# Altium schematic sheet Bramka_Main.SchDoc
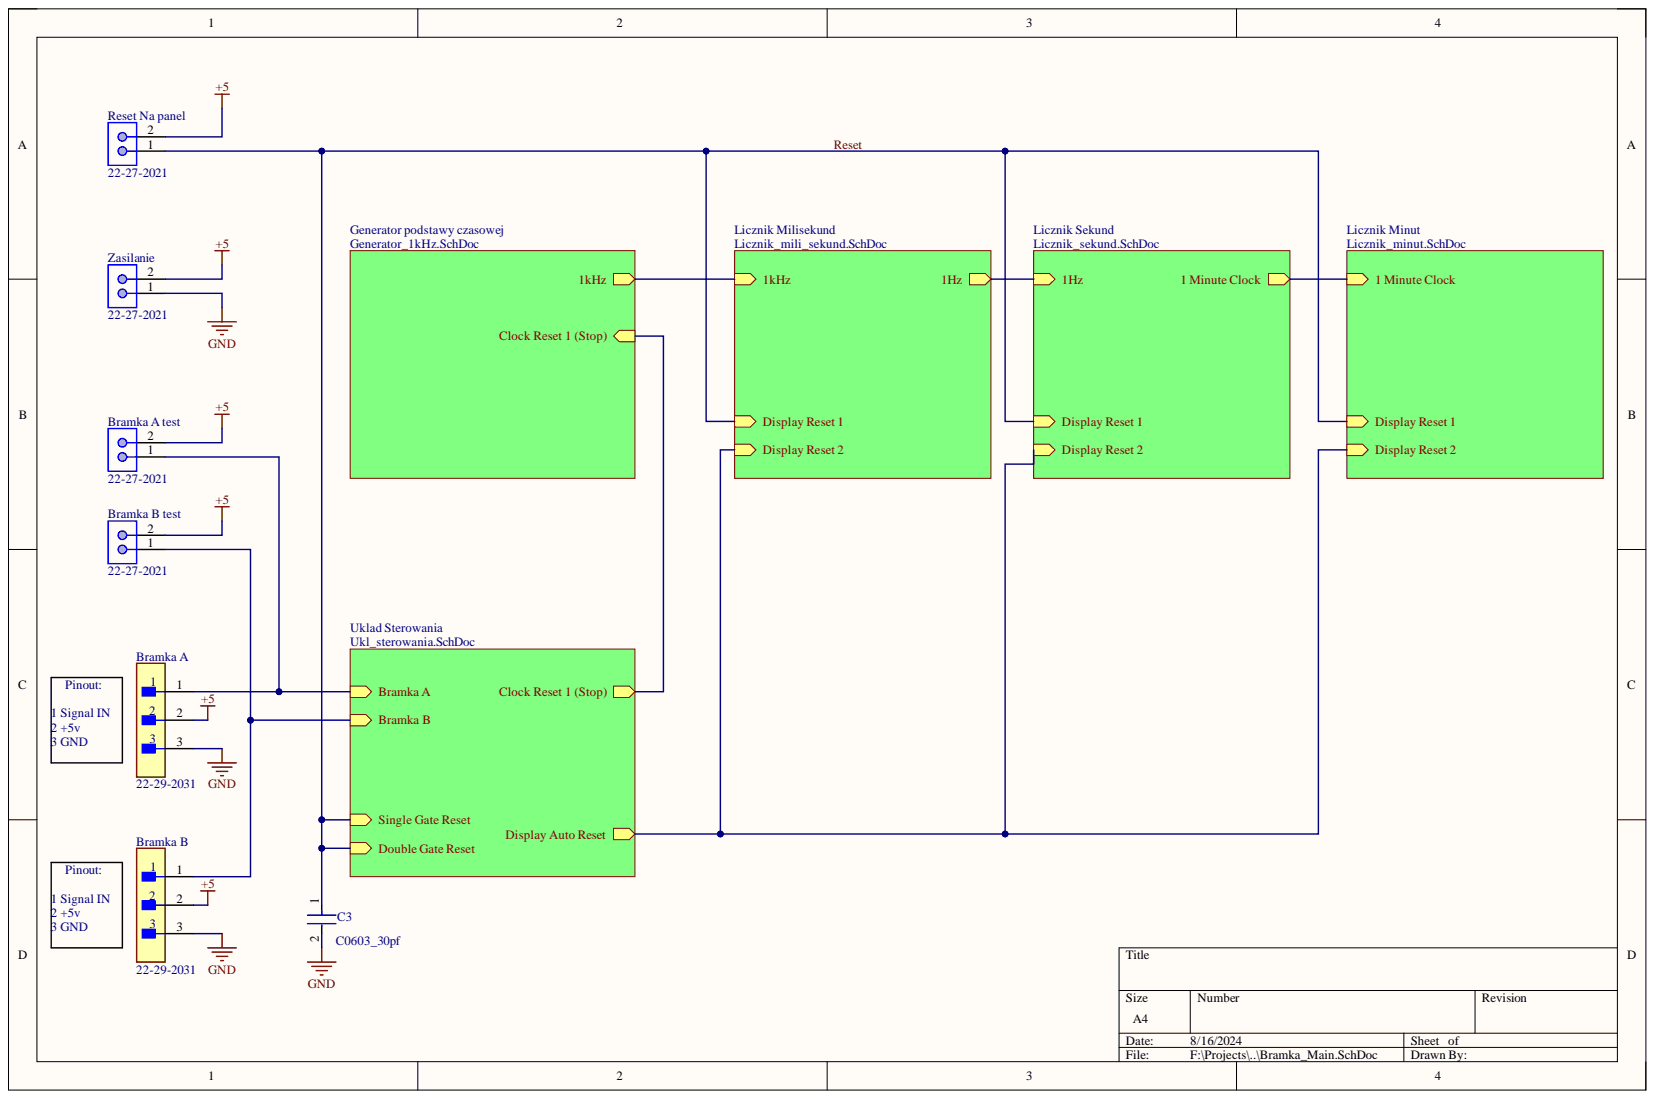
[diagram p0: Bramka_Main.SchDoc]

## Parts
Bramka_A  22-29-2031  [FP-22-29-2031-MFG]
Bramka_A_test  22-27-2021  [MOLX-22-27-2021_V]
Bramka_B  22-29-2031  [FP-22-29-2031-MFG]
Bramka_B_test  22-27-2021  [MOLX-22-27-2021_V]
C3  C0603_30pf  [FP-C0603C-CF-MFG]
Reset_Na_panel  22-27-2021  [MOLX-22-27-2021_V]
Zasilanie  22-27-2021  [MOLX-22-27-2021_V]

## Nets
+5: Bramka_A.2 Bramka_A_test.2 Bramka_B.2 Bramka_B_test.2 Reset_Na_panel.2 Zasilanie.2
GND: Bramka_A.3 Bramka_B.3 C3.2 Zasilanie.1
Reset: C3.1 Reset_Na_panel.1
NetBramka A test_1: Bramka_A.1 Bramka_A_test.1
NetBramka B test_1: Bramka_B.1 Bramka_B_test.1
1 Minute Clock:
1Hz:
1kHz:
Clock Reset 1 (Stop):
Display Auto Reset:

## Library data of the parts
Bramka_A Bramka_B = CMP-04776-000327-1: CONN HEADER VERT 3POS 2.54MM; Connector Type: Male Pin; Contact Resistance: 10mR; Current Rating: 4A; Gender: Male; Number of Contacts: 3; Voltage Rating: 250V; Color: Natural; Component Kind: Standard; Contact Material: Brass; Contact Mating Length: 0.295inch; Contact Plating: Gold; Contact Type: Male Pin; Depth: 5.8mm; Fastening Type: Friction; Housing Color: White; Housing Material: Nylon; Lead Pitch: 2.54mm; Length: 7.62mm; Library Name: Altium Content Vault; Max Operating Temperature: 75°C; Min Operating Temperature: 0°C; Mount: Through Hole; Number of Positions: 3; Number of Rows: 1; Orientation: Straight; Packaging: Bulk; Pitch: 2.54mm; Radiation Hardening: No; RoHS Compliant: Yes; Series: KK® 6410; Termination: Solder; Working Voltage: 250V
Bramka_A_test Bramka_B_test Reset_Na_panel Zasilanie = CMP-2000-05537-1: Male Header, Pitch 2.54 mm, 1 x 2 Position, Height 11.7 mm, Tail Length 3.56 mm, 0 to 75 degC, RoHS, Bulk; Manufacturer: Molex; PartNumber: 0022272021; Current - Max: 4A; No. of Positions: 2; No. of Rows: 1; Package Version: Rev. BC, 02/2012; Pitch: 2.54mm; Voltage - Max: 250V; Component Kind: Standard; Contact material: Tinplatedbrass; Current rating: 4A; Datasheet URL: http://www.molex.com/pdm_docs/sd/022272021_sd.pdf; Datasheet Version: Rev. F, 06/2009; Housing material: Nylon(UL94V-0); Library Name: Altium Content Vault; Manufacturer URL: http://www.molex.com/; Mounting Technology: ThroughHole; Operating temperature: 0°Cto+75°C; Orientation: Vertical; Package Description: 2-Leads Header, Body 5.08 x 5.8 mm, Pitch 2.54 mm; Package Reference: 22-27-2021; Voltage rating: 250V
C3 = CMP-1035-00914-3: CAP CER 30PF 50V 5% NP0 0603; Manufacturer: KEMET; Manufacturer Part Number: C0603C300J5GACTU; Automotive Grade: Grade 1; Material: Ceramic; Temperature Characteristic: C0G; Temperature Coefficient: 30ppm/°C; Tolerance: 0.05; Automotive: Yes; Capacitance: 30 pF; Category: Cap; Component Kind: Standard; ComponentLink2Description: Datasheet; ComponentLink2URL: https://api.kemet.com/component-edge/download/datasheet/C0603C100J5GACAUTO.pdf; Device Class L1: Passive Components; Device Class L2: Capacitors; Device Class L3: Ceramic Capacitors; Digikey Description: CAP CER 30PF 50V 5% NP0 0603; Height: 0.87mm; Lead Free: Yes; Library Name: Altium Content Vault; Mouser Description: Multilayer Ceramic Capacitors MLCC - SMD/SMT 50volts 30pF C0G 5%; Octopart: https://octopart.com/c0603c300j5gactu-kemet-199455; Package: C0603C-CF; RoHS: TRUE; Temperature: -55°C to +125°C; Temperature Range High: +125°C; Temperature Range Low: -55°C; Value: 30 pF; Voltage Rating: 50 V
